# Altium schematic sheet InfoDisplayAudio.SchDoc
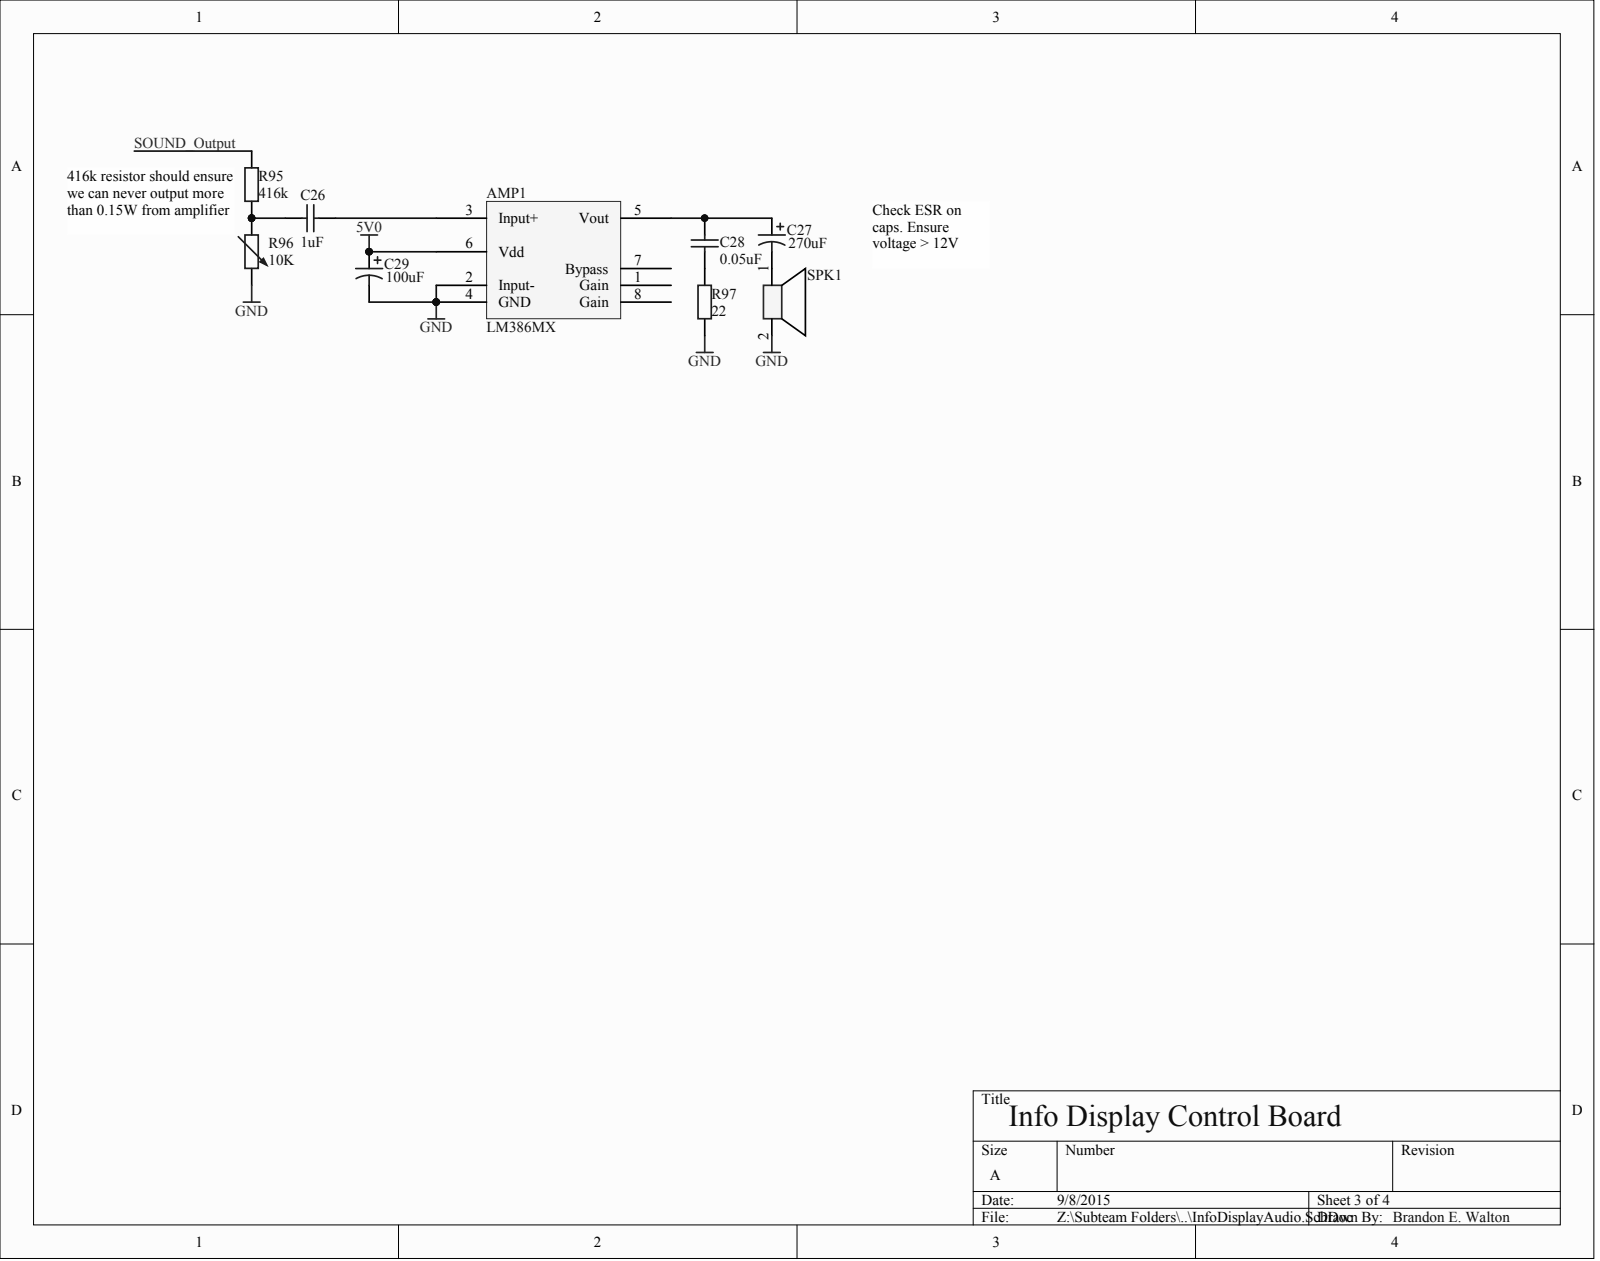
[diagram p0: InfoDisplayAudio.SchDoc]

## Parts
AMP1  LM386MX
C26  value 1uF  (hidden comment: GRM155R60J105KE19D)
C27  value 270uF  (hidden comment: EEU-FR1A271)
C28  value 0.05uF  (hidden comment: GRM033R61E472KA12D)
C29  value 100uF  (hidden comment: F930J107MAA)
R95  value 416k  (hidden comment: RC0603FR-07422KL)
R96  value 10K  (hidden comment: PVZ3A103C01R00)
R97  value 22  (hidden comment: Res2)
SPK1  PUI AST-03008MR-R  (value not drawn)

## Nets
5V0: AMP1.6(Vdd) C29.1
GND: AMP1.2(Input-) AMP1.4(GND) C29.2 R96.2 R97.2 SPK1.2
SOUND_OUTPUT: R95.2
NetAMP1_3: AMP1.3(Input+) C26.2
NetAMP1_5: AMP1.5(Vout) C27.1 C28.2
NetC26_1: C26.1 R95.1 R96.1
NetC27_2: C27.2 SPK1.1
NetC28_1: C28.1 R97.1

Not connected: AMP1 1(Gain) 7(Bypass) 8(Gain)

## Library data of the parts
AMP1 = (no symbol name): Partnumber: LM386MX-1/NOPB; Digikey: LM386MX-1/NOPBCT-ND
R96 = (no symbol name): Set Position: 0.5; Published: 8-Jun-2000; LatestRevisionDate: 17-Jul-2002; LatestRevisionNote: Re-released for DXP Platform.; PackageReference: AXIAL-0.6; PackageDescription: Axial Device, Thru-Hole; 2 Leads; 0.6 in Pin Spacing; Digikey: 490-7005-1-ND; Partnumber: PVZ3A103C01R00; Publisher: Altium Limited
R97 = (no symbol name): PartNumber: CRCW120622R0JNEA; Published: 8-Jun-2000; LatestRevisionDate: 17-Jul-2002; LatestRevisionNote: Re-released for DXP Platform.; PackageReference: AXIAL-0.4; PackageDescription: Axial Device, Thru-Hole; 2 Leads; 0.4 in Pin Spacing; Digikey: 541-22ECT-ND; Publisher: Altium Limited
C26-C29 R95 SPK1 = (no symbol name)
  C26: Published: 8-Jun-2000; LatestRevisionDate: 17-Jul-2002; LatestRevisionNote: Re-released for DXP Platform.; PackageReference: RAD-0.3; PackageDescription: Radial Cap, Thru-Hole; 2 Leads; 0.3 in Pin Spacing; Digikey: 490-1320-1-ND; PartNumber: GRM155R60J105KE19D
  C27: Published: 8-Jun-2000; LatestRevisionDate: 17-Jul-2002; LatestRevisionNote: Re-released for DXP Platform.; PackageReference: RB7.6-15; PackageDescription: Polarized Capacitor (Radial); 2 Leads; Digikey: P16745-ND; Partnumber: EEU-FR1A271
  C28: Published: 8-Jun-2000; LatestRevisionDate: 17-Jul-2002; LatestRevisionNote: Re-released for DXP Platform.; PackageReference: RAD-0.3; PackageDescription: Radial Cap, Thru-Hole; 2 Leads; 0.3 in Pin Spacing; Digikey: 490-9993-1-ND; PartNumber: GRM033R61E472KA12D
  C29: Published: 8-Jun-2000; LatestRevisionDate: 17-Jul-2002; LatestRevisionNote: Re-released for DXP Platform.; PackageReference: RB7.6-15; PackageDescription: Polarized Capacitor (Radial); 2 Leads; Digikey: 478-8155-1-ND; Partnumber: F930J107MAA
  R95: Published: 8-Jun-2000; LatestRevisionDate: 17-Jul-2002; LatestRevisionNote: Re-released for DXP Platform.; PackageReference: AXIAL-0.4; PackageDescription: Axial Device, Thru-Hole; 2 Leads; 0.4 in Pin Spacing; Digikey: 311-422KHRCT-ND; Partnumber: RC0603FR-07422KL
  SPK1: Digikey: 668-1136-ND; Partnumber: AST-03008MR-R
  C26-C29 R95: Publisher: Altium Limited
